# Altium schematic sheet TC106_v012.SchDoc(TC106_v012)
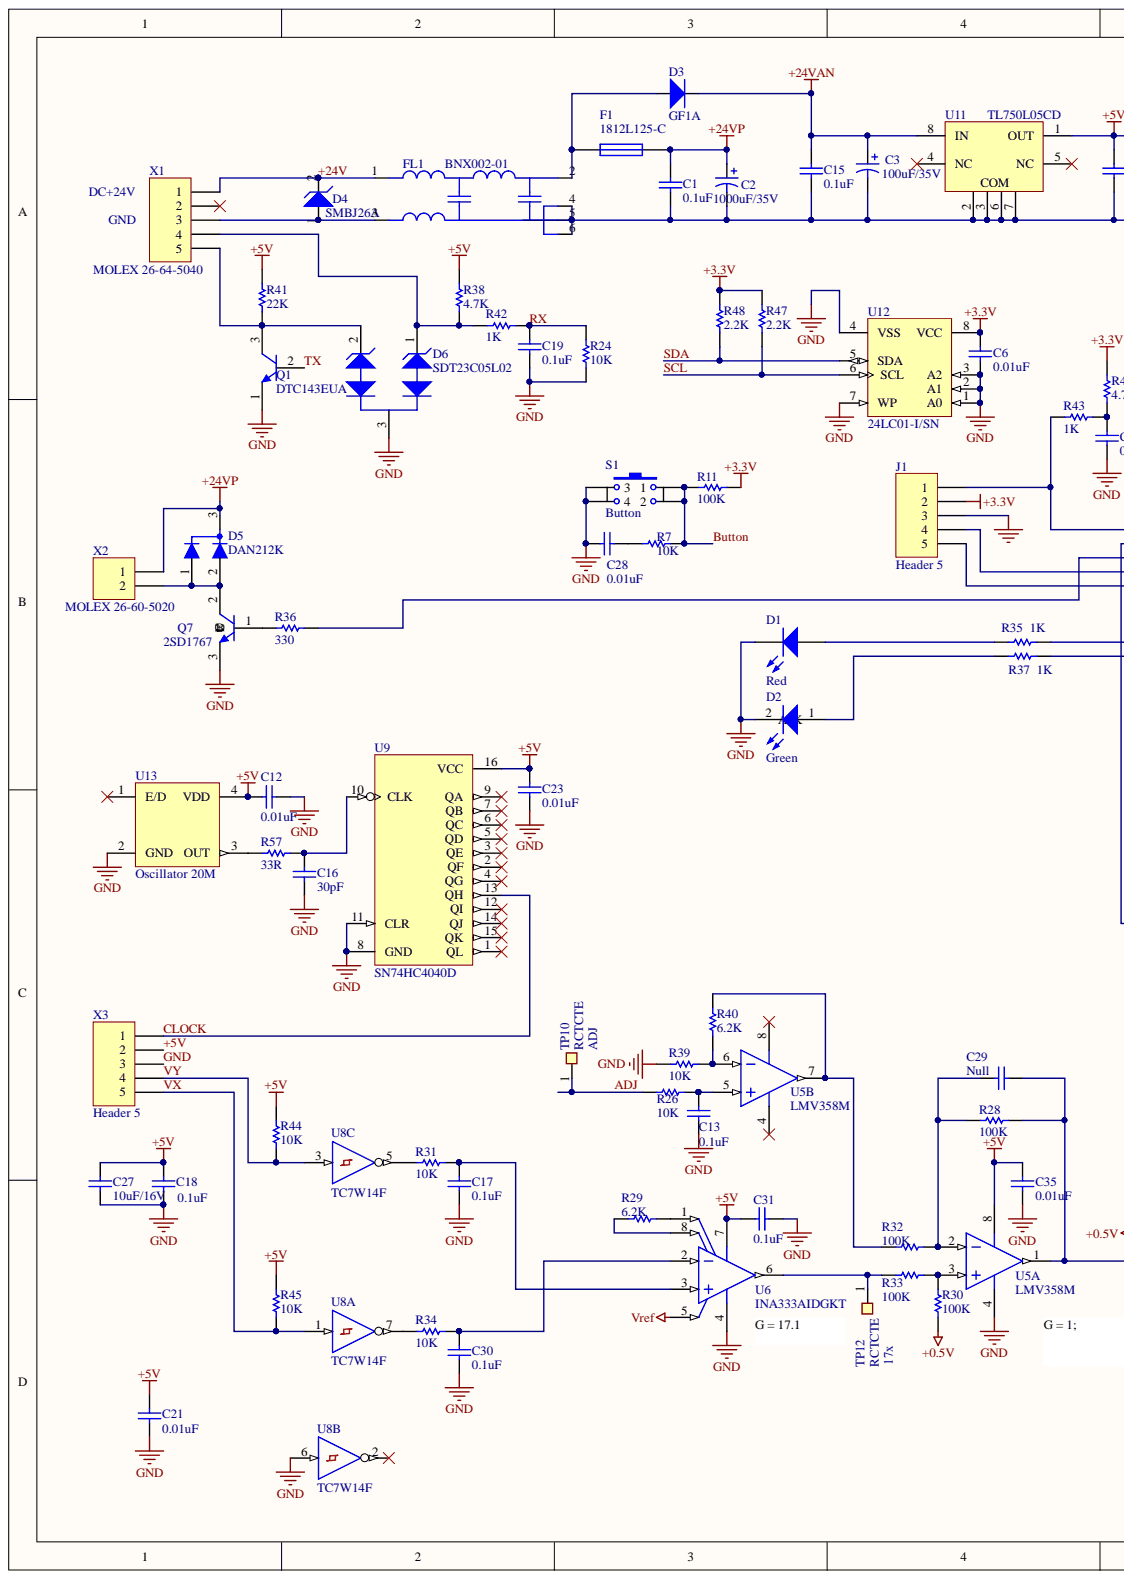
[diagram p0: TC106_v012.SchDoc, left]
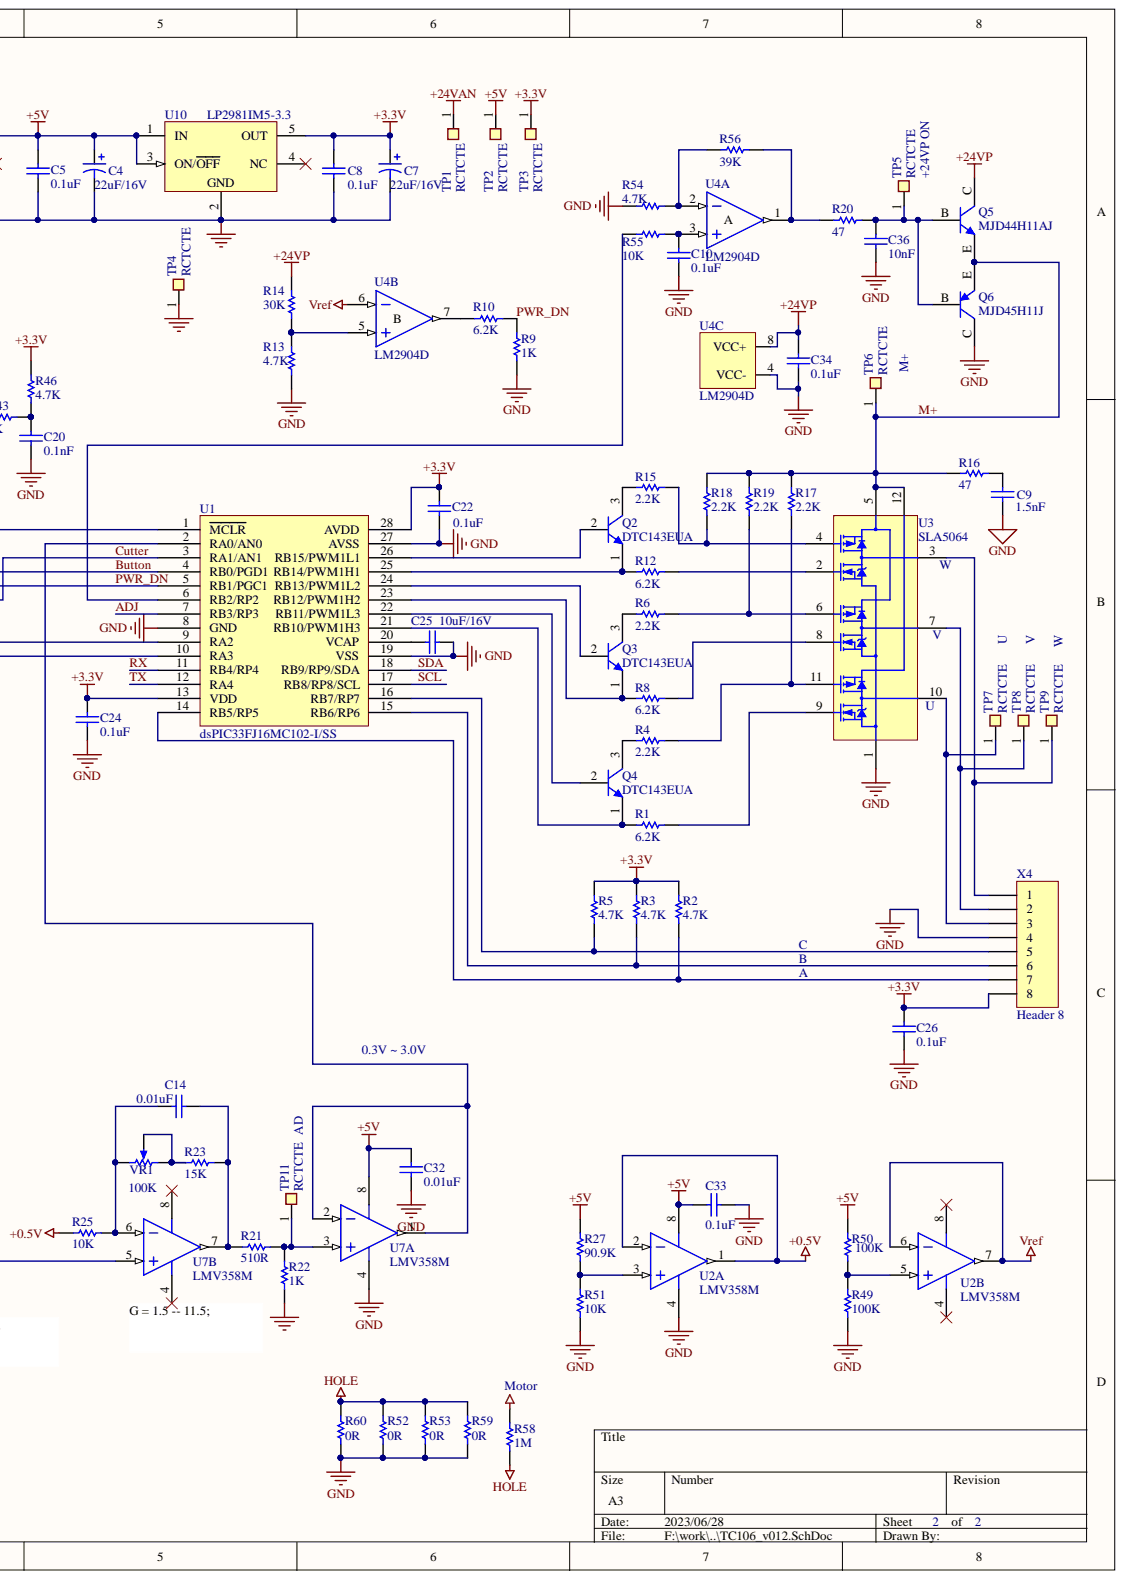
[diagram p1: TC106_v012.SchDoc, right]

## Parts
C1  0.1uF  [C0805-D]
C2  1000uF/35V  [RB.2/.5_M]
C3  100uF/35V  [E CAP D]
C4  22uF/16V  [E CAP B]
C5  0.1uF  [C0805-D]
C6  0.01uF  [C0805-D]
C7  22uF/16V  [E CAP B]
C8  0.1uF  [C0805-D]
C9  1.5nF  [C0805-D]
C10  0.1uF  [C0805-D]
C12  0.01uF  [C0805-D]
C13  0.1uF  [C0805-D]
C14  0.01uF  [C0805-D]
C15  0.1uF  [C0805-D]
C16  30pF  [C0805-D]
C17  0.1uF  [C0805-D]
C18  0.1uF  [C0805-D]
C19  0.1uF  [C0805-D]
C20  0.1nF  [C0805-D]
C21  0.01uF  [C0805-D]
C22  0.1uF  [C0805-D]
C23  0.01uF  [C0805-D]
C24  0.1uF  [C0805-D]
C25  10uF/16V  [C0805-D]
C26  0.1uF  [C0805-D]
C27  10uF/16V  [C0805-D]
C28  0.01uF  [C0805-D]
C29  Null  [C0805-D]
C30  0.1uF  [C0805-D]
C31  0.1uF  [C0805-D]
C32  0.01uF  [C0805-D]
C33  0.1uF  [C0805-D]
C34  0.1uF  [C0805-D]
C35  0.01uF  [C0805-D]
C36  10nF  [C0805-D]
D1  Red  [LED1206-D]
D2  Green  [LED1206-D]
D3  GF1A  [SMA]
D4  SMBJ26A  [Diode-SMBJ]
D5  DAN212K  [SOT23_B]
D6  SDT23C05L02  [sot23]
F1  1812L125-C  [R1812-D]
FL1  BNX002-01  [FILTER_BNX002-01]
J1  Header 5  [HDR1X5]
Motor  Motor-BLDC45  [Motor_BLDC45]  (value not drawn)
Q1  DTC143EUA  [SOT323B]
Q2  DTC143EUA  [SOT323B]
Q3  DTC143EUA  [SOT323B]
Q4  DTC143EUA  [SOT323B]
Q5  MJD44H11AJ  [MJD44H11AJ]
Q6  MJD45H11J  [MJD45H11J]
Q7  2SD1767  [SOT89]
R1  6.2K  [R0805-D]
R2  4.7K  [R0805-D]
R3  4.7K  [R0805-D]
R4  2.2K  [R0805-D]
R5  4.7K  [R0805-D]
R6  2.2K  [R0805-D]
R7  10K  [R0805-D]
R8  6.2K  [R0805-D]
R9  1K  [R0805-D]
R10  6.2K  [R0805-D]
R11  100K  [R0805-D]
R12  6.2K  [R0805-D]
R13  4.7K  [R0805-D]
R14  30K  [R0805-D]
R15  2.2K  [R0805-D]
R16  47  [R0805-D]
R17  2.2K  [R0805-D]
R18  2.2K  [R0805-D]
R19  2.2K  [R0805-D]
R20  47  [R0805-D]
R21  510R  [R0805-D]
R22  1K  [R0805-D]
R23  15K  [R0805-D]
R24  10K  [R0805-D]
R25  10K  [R0805-D]
R26  10K  [R0805-D]
R27  90.9K  [R0805-D]
R28  100K  [R0805-D]
R29  6.2K  [R0805-D]
R30  100K  [R0805-D]
R31  10K  [R0805-D]
R32  100K  [R0805-D]
R33  100K  [R0805-D]
R34  10K  [R0805-D]
R35  1K  [R0805-D]
R36  330  [R0805-D]
R37  1K  [R0805-D]
R38  4.7K  [R0805-D]
R39  10K  [R0805-D]
R40  6.2K  [R0805-D]
R41  22K  [R0805-D]
R42  1K  [R0805-D]
R43  1K  [R0805-D]
R44  10K  [R0805-D]
R45  10K  [R0805-D]
R46  4.7K  [R0805-D]
R47  2.2K  [R0805-D]
R48  2.2K  [R0805-D]
R49  100K  [R0805-D]
R50  100K  [R0805-D]
R51  10K  [R0805-D]
R52  0R  [R0805-D]
R53  0R  [R0805-D]
R54  4.7K  [R0805-D]
R55  10K  [R0805-D]
R56  39K  [R0805-D]
R57  33R  [R0805-D]
R58  1M  [R0805-D]
R59  0R  [R0805-D]
R60  0R  [R0805-D]
S1  Button  [sw_button 6*6]
TP1  RCTCTE  [RCTCTE]
TP2  RCTCTE  [RCTCTE]
TP3  RCTCTE  [RCTCTE]
TP4  RCTCTE  [RCTCTE]
TP5  RCTCTE  [RCTCTE]
TP6  RCTCTE  [RCTCTE]
TP7  RCTCTE  [RCTCTE]
TP8  RCTCTE  [RCTCTE]
TP9  RCTCTE  [RCTCTE]
TP10  RCTCTE  [RCTCTE]
TP11  RCTCTE  [RCTCTE]
TP12  RCTCTE  [RCTCTE]
U1  dsPIC33FJ16MC102-I/SS  [SSOP-SS28_M]
U2  LMV358M  [M08A_M]  (parts A,B)
U3  SLA5064  [SLA5064]
U4  LM2904D  [SO-8]  (parts A,B,C)
U5  LMV358M  [M08A_M]  (parts A,B)
U6  INA333AIDGKT  [TI-DGK8_M]
U7  LMV358M  [M08A_M]  (parts A,B)
U8  TC7W14F  [TC7W14FU]  (parts A,B,C)
U9  SN74HC4040D  [SO-16]
U10  LP2981IM5-3.3  [MF05A_N]
U11  TL750L05CD  [TI-D8_N]
U12  24LC01-I/SN  [SO-8]
U13  Oscillator 20M  [OSC7050]
VR1  100K  [POT-3266W-1]
X1  MOLEX 26-64-5040  [MOLEX 26-64-5040]
X2  MOLEX 26-60-5020  [MOLEX 26-60-5020]
X3  Header 5  [molex 52271-0569]
X4  Header 8  [HX25035-8A]

## Nets
+0.5V: R25.1 R30.1 U2.1 U2.2
+3.3V: C6.2 C7.1 C8.2 C22.2 C24.2 C26.1 J1.2 R2.2 R3.2 R5.2 R11.1 R46.1 R47.2 R48.2 TP3.1 U1.13(VDD) U1.28(AVDD) U10.5(OUT) U12.8(VCC) X4.8
+24VAN: C3.1 C15.2 D3.2 TP1.1 U11.8(IN)
+24VP: C1.2 C2.1 C34.2 D5.3 F1.2 Q5.C R14.2 U4.8(VCC+) X2.1
HOLE: R52.1 R53.1 R58.1 R59.1 R60.1
Vref: U2.6 U2.7 U4.6 U6.5
+5V: C4.1 C5.2 C12.2 C18.2 C21.1 C23.2 C27.1 C31.1 C32.1 C33.1 C35.1 R27.2 R38.2 R41.2 R44.2 R45.2 R50.2 TP2.1 U2.8 U5.8 U6.7 U7.8 U8.8 U9.16(VCC) U10.1(IN) U10.3(ON/OFF) U11.1(OUT) U13.4(VDD) X3.2
+24V: D4.2 FL1.1 X1.1
ADJ: R26.2 TP10.1 U1.7(RB3/RP3)
Button: J1.4 R7.1 R11.2 S1.1 S1.2 U1.4(RB0/PGD1)
CLOCK: U9.13(QH) X3.1
Cutter: R36.2 U1.3(RA1/AN1)
GND: C1.1 C2.2 C3.2 C4.2 C5.1 C6.1 C7.2 C8.1 C9.1 C10.1 C12.1 C13.1 C15.1 C16.1 C17.1 C18.1 C19.1 C20.1 C21.2 C22.1 C23.1 C24.1 C25.1 C26.2 C27.2 C28.1 C30.1 C31.2 C32.2 C33.2 C34.1 C35.2 C36.1 D1.2 D2.2 D6.3 FL1.4 FL1.5 FL1.6 J1.3 Q1.1 Q6.C Q7.3 R9.1 R13.1 R22.2 R24.1 R39.2 R49.1 R51.1 R52.2 R53.2 R54.1 R59.2 R60.2 S1.3 S1.4 TP4.1 U1.8(GND) U1.19(VSS) U1.27(AVSS) U2.4 U3.1 U4.4(VCC-) U5.4 U6.4 U7.4 U8.4 U8.6 U9.8(GND) U9.11(CLR) U10.2 U11.2 U11.3 U11.6 U11.7 U12.1(A0) U12.2(A1) U12.3(A2) U12.4(VSS) U12.7(WP) U13.2(GND) X3.3 X4.4
M+: Q5.E Q6.E R16.2 R17.2 R18.2 R19.1 TP6.1 U3.5 U3.12
PWR_DN: J1.5 R9.2 R10.1 U1.5(RB1/PGC1)
RX: C19.2 R24.2 R42.1 U1.11(RB4/RP4)
SCL: R47.1 U1.17(RB8/RP8/SCL) U12.6(SCL)
SDA: R48.1 U1.18(RB9/RP9/SDA) U12.5(SDA)
TX: Q1.2 U1.12(RA4)
VX: R45.1 U8.1 X3.5
VY: R44.1 U8.3 X3.4
NetC9_2: C9.2 R16.1
NetC10_2: C10.2 R55.1 U4.3
NetC13_2: C13.2 R26.1 U5.5
NetC14_1: C14.1 R25.2 U7.6 VR1.2
NetC14_2: C14.2 R21.2 R23.2 U7.7
NetC16_2: C16.2 R57.2 U9.10(CLK)
NetC17_2: C17.2 R31.2 U6.3
NetC20_2: C20.2 R43.1 R46.2
NetC25_2: C25.2 U1.20(VCAP)
NetC28_2: C28.2 R7.2
NetC29_1: C29.1 R28.1 R32.2 U5.2
NetC29_2: C29.2 R28.2 U5.1 U7.5
NetC30_2: C30.2 R34.2 U6.2
NetC36_2: C36.2 Q5.B Q6.B R20.2 TP5.1
NetD1_1: D1.1 R35.2
NetD2_1: D2.1 R37.2
NetD3_1: D3.1 F1.1 FL1.2
NetD4_1: D4.1 FL1.3 X1.3
NetD5_1: D5.1 D5.2 Q7.2 X2.2
NetD6_1: D6.1 R38.1 R42.2 X1.4
NetD6_2: D6.2 Q1.3 R41.1 X1.5
NetJ1_1: J1.1 R43.2 U1.1(MCLR)
NetMotor_1: Motor.1 R58.2
NetQ2_1: Q2.1 R12.1 U1.25(RB14/PWM1H1)
NetQ2_2: Q2.2 U1.26(RB15/PWM1L1)
NetQ2_3: Q2.3 R15.1
NetQ3_1: Q3.1 R8.1 U1.23(RB12/PWM1H2)
NetQ3_2: Q3.2 U1.24(RB13/PWM1L2)
NetQ3_3: Q3.3 R6.1
NetQ4_1: Q4.1 R1.1 U1.21(RB10/PWM1H3)
NetQ4_2: Q4.2 U1.22(RB11/PWM1L3)
NetQ4_3: Q4.3 R4.1
NetQ7_1: Q7.1 R36.1
NetR1_2: R1.2 U3.9
NetR2_1: R2.1 U1.14(RB5/RP5) X4.7
NetR3_1: R3.1 U1.15(RB6/RP6) X4.6
NetR4_2: R4.2 R17.1 U3.11
NetR5_1: R5.1 U1.16(RB7/RP7) X4.5
NetR6_2: R6.2 R19.2 U3.6
NetR8_2: R8.2 U3.8
NetR10_2: R10.2 U4.7
NetR12_2: R12.2 U3.2
NetR13_2: R13.2 R14.1 U4.5
NetR15_2: R15.2 R18.1 U3.4
NetR20_1: R20.1 R56.1 U4.1
NetR21_1: R21.1 R22.1 TP11.1 U7.3
NetR23_1: R23.1 VR1.1 VR1.3
NetR27_1: R27.1 R51.2 U2.3
NetR29_1: R29.1 U6.8
NetR29_2: R29.2 U6.1
NetR30_2: R30.2 R33.2 U5.3
NetR31_1: R31.1 U8.5
NetR32_1: R32.1 R40.2 U5.7
NetR33_1: R33.1 TP12.1 U6.6
NetR34_1: R34.1 U8.7
NetR35_1: R35.1 U1.9(RA2)
NetR37_1: R37.1 U1.10(RA3)
NetR39_1: R39.1 R40.1 U5.6
NetR49_2: R49.2 R50.1 U2.5
NetR54_2: R54.2 R56.2 U4.2
NetR55_2: R55.2 U1.6(RB2/RP2)
NetR57_1: R57.1 U13.3(OUT)
NetTP7_1: TP7.1 U3.10 X4.3
NetTP8_1: TP8.1 U3.7 X4.2
NetTP9_1: TP9.1 U3.3 X4.1
NetU1_2: U1.2(RA0/AN0) U7.1 U7.2

Not connected: U8 2; U9 1(QL) 2(QF) 3(QE) 4(QG) 5(QD) 6(QC) 7(QB) 9(QA) 12(QI) 14(QJ) 15(QK); U10 4(NC); U11 4(NC) 5(NC); U13 1(E/D); X1 2

## Library data of the parts
C1 C6 C10 C12 C14 C17 C22 C23 C26 C30 C31 = Cap: Capacitor; Simulation: CAP; Signal Integrity: Cap; Published: 8-Jun-2000; PackageReference: RAD-0.3; Library Name: Miscellaneous Devices.IntLib; LatestRevisionNote: Re-released for DXP Platform.; LatestRevisionDate: 17-Jul-2002; Component Kind: Standard; Publisher: Altium Limited
  C1 C10 C17 C22 C26 C30 C31: Value: 0.1uF
  C6 C12 C14 C23: Value: 0.01uF
C2 C4 C7 = Cap Pol2: Polarized Capacitor (Axial); Simulation: CAP; Signal Integrity: Cap Pol; Published: 8-Jun-2000; PackageReference: POLAR0.8; Library Name: Miscellaneous Devices.IntLib; LatestRevisionNote: Re-released for DXP Platform.; LatestRevisionDate: 17-Jul-2002; Component Kind: Standard; Publisher: Altium Limited
  C2: Value: 1000uF/35V
  C4 C7: Value: 22uF/16V
C3 = Cap Pol2: Polarized Capacitor (Axial); Value: 22uF/35V; Simulation: CAP; Signal Integrity: Cap Pol; Published: 8-Jun-2000; PackageReference: POLAR0.8; Library Name: Miscellaneous Devices.IntLib; LatestRevisionNote: Re-released for DXP Platform.; LatestRevisionDate: 17-Jul-2002; Component Kind: Standard; Publisher: Altium Limited
C5 = Cap: Capacitor; Value: 100nF; Simulation: CAP; Signal Integrity: Cap; Published: 8-Jun-2000; PackageReference: RAD-0.3; Library Name: my_pcblib.pcblib; LatestRevisionNote: Re-released for DXP Platform.; LatestRevisionDate: 17-Jul-2002; Component Kind: Standard; Publisher: Altium Limited
C8 C13 C16 C24 C33 C34 = Cap: Capacitor; Value: 0.01uF; Simulation: CAP; Signal Integrity: Cap; Published: 8-Jun-2000; PackageReference: RAD-0.3; Library Name: Miscellaneous Devices.IntLib; LatestRevisionNote: Re-released for DXP Platform.; LatestRevisionDate: 17-Jul-2002; Component Kind: Standard; Publisher: Altium Limited
C9 = Cap: Capacitor; Value: 2.2nF; Simulation: CAP; Signal Integrity: Cap; Published: 8-Jun-2000; PackageReference: RAD-0.3; Library Name: Miscellaneous Devices.IntLib; LatestRevisionNote: Re-released for DXP Platform.; LatestRevisionDate: 17-Jul-2002; Component Kind: Standard; Publisher: Altium Limited
C15 C19 = Cap: Capacitor; Value: 0.22uF; Simulation: CAP; Signal Integrity: Cap; Published: 8-Jun-2000; PackageReference: RAD-0.3; Library Name: Miscellaneous Devices.IntLib; LatestRevisionNote: Re-released for DXP Platform.; LatestRevisionDate: 17-Jul-2002; Component Kind: Standard; Publisher: Altium Limited
C18 = Cap: Capacitor; Value: 1uF; Simulation: CAP; Signal Integrity: Cap; Published: 8-Jun-2000; PackageReference: RAD-0.3; Library Name: Miscellaneous Devices.IntLib; LatestRevisionNote: Re-released for DXP Platform.; LatestRevisionDate: 17-Jul-2002; Component Kind: Standard; Publisher: Altium Limited
C20 C36 = Cap: Capacitor; Value: 1500pF; Simulation: CAP; Signal Integrity: Cap; Published: 8-Jun-2000; PackageReference: RAD-0.3; Library Name: Miscellaneous Devices.IntLib; LatestRevisionNote: Re-released for DXP Platform.; LatestRevisionDate: 17-Jul-2002; Component Kind: Standard; Publisher: Altium Limited
C21 = Cap: Capacitor; Value: 0.01nF; Simulation: CAP; Signal Integrity: Cap; Published: 8-Jun-2000; PackageReference: RAD-0.3; Library Name: Miscellaneous Devices.IntLib; LatestRevisionNote: Re-released for DXP Platform.; LatestRevisionDate: 17-Jul-2002; Component Kind: Standard; Publisher: Altium Limited
C25 C27 = Cap: Capacitor; Value: 10uF; Simulation: CAP; Signal Integrity: Cap; Published: 8-Jun-2000; PackageReference: RAD-0.3; Library Name: Miscellaneous Devices.IntLib; LatestRevisionNote: Re-released for DXP Platform.; LatestRevisionDate: 17-Jul-2002; Component Kind: Standard; Publisher: Altium Limited
C28 = Cap Semi: Capacitor (Semiconductor SIM Model); Value: 100pF; Simulation: CAP; Signal Integrity: Cap Semi; Published: 8-Jun-2000; PackageReference: C1206; Library Name: my Devices.SchLib; LatestRevisionNote: Re-released for DXP Platform.; LatestRevisionDate: 17-Jul-2002; Component Kind: Standard; Publisher: Altium Limited
C29 C32 C35 = Cap: Capacitor; Value: 0.1uF; Simulation: CAP; Signal Integrity: Cap; Published: 8-Jun-2000; PackageReference: RAD-0.3; Library Name: Miscellaneous Devices.IntLib; LatestRevisionNote: Re-released for DXP Platform.; LatestRevisionDate: 17-Jul-2002; Component Kind: Standard; Publisher: Altium Limited
D1 D2 = LED2: Typical RED, GREEN, YELLOW, AMBER GaAs LED; Simulation: LED2; Published: 8-Jun-2000; PackageReference: 3.2X1.6X1.1; PackageDocument: Feb-2002; Library Name: Miscellaneous Devices.IntLib; LatestRevisionNote: Re-released for DXP Platform.; LatestRevisionDate: 17-Jul-2002; Component Kind: Standard; Publisher: Altium Limited
D3 = Diode: Default Diode; Simulation: DIODE; Signal Integrity: Diode; Published: 8-Jun-2000; PackageReference: SMC; PackageDocument: Sep-1996; Library Name: my Devices.SchLib; LatestRevisionNote: Re-released for DXP Platform.; LatestRevisionDate: 17-Jul-2002; Component Kind: Standard; Code_JEDEC: DO-214-AB; Publisher: Altium Limited
D4 = Diode TVS: TVS,VR 26V,600w,SMB,UL RoHs; Simulation: DIODE; Signal Integrity: Diode; Published: 8-Jun-2000; PackageReference: SMC; PackageDocument: Sep-1996; Library Name: my Devices.SchLib; LatestRevisionNote: Re-released for DXP Platform.; LatestRevisionDate: 17-Jul-2002; Component Kind: Standard; Code_JEDEC: DO-214-AB; Publisher: Altium Limited
D6 = AD4492: DIODE, TRANSIENT SUPPRESSOR, DUAL, BIDIRECTIONAL, 5V, SOT23, LF; State: Released; ID: 69; DCOMP: PESD5V0S2BT; Database Table Name: Altium.AD-Diodes; Catalog: Transient Suppressors; Supplier Part Number 3: 38K6670; Supplier Part Number 2: 771-PESD5V0S2BT-T/R; Supplier Part Number 1: 568-4056-2-ND; Supplier 3: Newark; Supplier 2: Mouser; Supplier 1: Digi-Key; RoHS: RoHS2011; Part Number: AD4492; Component Kind: Standard; BOM_PN: AD4492; Library Name: CSH_DB_PROD.DbLib
F1 = Fuse 1: Fuse; Simulation: FUSE; Published: 8-Jun-2000; PackageReference: PIN-W2/E2.8; Library Name: Miscellaneous Devices.IntLib; LatestRevisionNote: Re-released for DXP Platform.; LatestRevisionDate: 17-Jul-2002; Component Kind: Standard; Publisher: Altium Limited
FL1 = 903884: FILTER,SINGLE,.5MHZ-1GHZ,50VDC, LF; State: Released; ID: 1; Database Table Name: Altium.AD-Misc; Catalog: Elect and EMI/RFI Filters; Supplier Part Number 3: 69K8511; Supplier Part Number 2: BNX002-01; Supplier Part Number 1: 490-5053-ND; Supplier 3: Newark; Supplier 2: Mouser; Supplier 1: Digi-Key; RoHS: RoHS2011; Part Number: 903884; DCOMP: BNX002-01; Component Kind: Standard; BOM_PN: 903884; Library Name: CSH_DB_PROD.DbLib
J1 X1 X3 = Header 5: Header, 5-Pin; Signal Integrity: Connector; Library Name: Miscellaneous Connectors.IntLib; LatestRevisionNote: Re-released for DXP Platform.; LatestRevisionDate: 17-Jul-2002; Component Kind: Standard; Publisher: Altium Limited
Q1-Q4 = NPN: NPN Bipolar Transistor; Simulation: NPN; Signal Integrity: NPN; Published: 8-Jun-2000; PackageReference: TO-226; Library Name: Miscellaneous Devices.IntLib; LatestRevisionNote: Re-released for DXP Platform.; LatestRevisionDate: 17-Jul-2002; Component Kind: Standard; Code_JEDEC: TO-226-AA; Publisher: Altium Limited
Q5 Q7 = 2N3904: NPN General Purpose Amplifier; Simulation: 2N3904; Signal Integrity: 2N3904; Published: 8-Jun-2000; PackageReference: TO-92A; PackageDocument: Sep-1998; Library Name: Miscellaneous Devices.IntLib; LatestRevisionNote: PCB Footprint 'BCY-W3/D4.7' replaced; LatestRevisionDate: 15-Jan-2003; DatasheetDocument: 1997; ComponentLink2URL: http://www.fairchildsemi.com/ds/2N%2F2N3904.pdf; ComponentLink2Description: Datasheet; ComponentLink1URL: http://www.fairchildsemi.com/; ComponentLink1Description: Manufacturer Link; Component Kind: Standard; Code_JEDEC: TO-92A; Publisher: Altium Limited
Q6 = 2N3906: PNP General Purpose Amplifier; Simulation: 2N3906; Signal Integrity: 2N3906; Published: 8-Jun-2000; PackageReference: TO-92A; PackageDocument: Sep-1998; Library Name: Miscellaneous Devices.IntLib; LatestRevisionNote: PCB Footprint 'BCY-W3/D4.7' replaced; LatestRevisionDate: 15-Jan-2003; DatasheetDocument: 1997; ComponentLink2URL: http://www.fairchildsemi.com/ds/2N%2F2N3906.pdf; ComponentLink2Description: Datasheet; ComponentLink1URL: http://www.fairchildsemi.com/; ComponentLink1Description: Manufacturer Link; Component Kind: Standard; Code_JEDEC: TO-92A; Publisher: Altium Limited
R1-R60 = Res1: Resistor; Simulation: RESISTOR; Signal Integrity: Res; Published: 8-Jun-2000; Library Name: Miscellaneous Devices.IntLib; LatestRevisionNote: Re-released for DXP Platform.; LatestRevisionDate: 17-Jul-2002; Component Kind: Standard; Publisher: Altium Limited
S1 = SW-PB_1: Switch; Published: 24-Mar-1999; PackageReference: SPST-2; Library Name: my Devices.SchLib; LatestRevisionNote: Re-released for DXP Platform.; LatestRevisionDate: 17-Jul-2002; Component Kind: Standard; Publisher: Altium Limited
TP1-TP12 = TP: Component Kind: Standard; Library Name: Asena.lib
U1 = dsPIC33FJ16MC102-I/SS: General Purpose 16-Bit Flash Microcontroller, 16KB Flash, 1KB SRAM, 28-Pin SSOP, Industrial Temperature; Library Name: my Devices.SchLib; Component Kind: Standard
U2 U5 U7 = LMV358M: General Purpose, Low Voltage, Rail-to-Rail Output Operational Amplifiers, 8-pin Narrow SOIC; Manufacturer: National Semiconductor; PowerWise: N; PackageTopMarking: NSZXTT*LMV*358M; High-Reliability: Y; RoHS: N; PinCount: 8; PartNumber: LMV358M; PackageVersion: revM, May-2009; PackageReference: M08A; PackageDescription: SOIC Narrow, 4.9 x 3.9 x 1.45mm, 8 Lead, 1.27mm Pitch; Library Name: National Semiconductor Amplifier.IntLib; DatasheetVersion: Sep-2009; CompType: Amplifiers; ComponentLink4URL: http://www.national.com/packaging/folders/m08a.html; ComponentLink4Description: M08A Package Page; ComponentLink3URL: http://www.national.com/pf/LM/LMV358.html; ComponentLink3Description: LMV358 Web Page; ComponentLink2URL: http://www.national.com/ds/LM/LMV358.pdf; ComponentLink2Description: LMV358 Datasheet; ComponentLink1URL: http://www.national.com/; ComponentLink1Description: Manufacturer URL; Component Kind: Standard; Code_JEDEC: MS-012-AA; Code_IPC: SOIC127P600-8
U3 = SLA5064: N-channel+P-channel, 3-phase motor drive; Library Name: my Devices.SchLib; Component Kind: Standard
U4 = LM2904D: Low-Power Dual Operational Amplifier; Simulation: LM2904; Published: 24-Aug-2001; PCB3D: SO8; PackageVersion: Dec-2002; PackageReference: SO8; PackageDescription: 8-Pin Small Outline Integrated Circuit 1.27 mm Pitch; Library Name: TC106_v012.SCHLIB; LatestRevisionNote: IPC-7351 Footprint Added.; LatestRevisionDate: 13-Apr-2006; DatasheetVersion: Jan-1999; ComponentLink2URL: http://www.st.com/stonline/products/literature/ds/2471/lm2904.pdf; ComponentLink2Description: Datasheet; ComponentLink1URL: http://www.st.com/; ComponentLink1Description: Manufacturer Link; Component Kind: Standard; Code_JEDEC: MS-012-AA; Code_IPC: SOIC127P600-8; Publisher: Altium Limited
U6 = INA333AIDGKT: Low Power, Precision Instrumentation Amplifier, -40 to 125 degC, 8-pin SOP (DGK8), Green (RoHS & no Sb/Br); Manufacturer: Texas Instruments; Vs(Min)(V): 1.8; Vs(Max)(V): 5.5; SubFamily: Single Supply; Quiescent Current (+/-)(Typ)(mA): 0.05; Output Offset Drift (+/-)(Max)(uV/Degrees Celsius): 0.5/G; Output Offset (+/-)(Max)(uV): 75/G; Number of Channels: 1; Noise at 1kHz(Typ)(nV/rt(Hz)): 50; Input Offset Drift (+/-)(Max)(uV/Degrees Celsius): 0.1; Input Offset (+/-)(Max)(uV): 25; Input Bias Current (+/-)(Max)(nA): 0.2; Gain(V/V): 1 to 1000; CMRR(Min)(dB): 100; Supplier Part Number 7: 595-INA333AIDGKT; Supplier Part Number 6: 03P4517; Supplier Part Number 5: 86W6747; Supplier Part Number 4: 1645386; Supplier Part Number 3: 296-23565-6-ND; Supplier Part Number 2: 296-23565-1-ND; Supplier Part Number 1: 296-23565-2-ND; Supplier 7: Mouser; Supplier 6: Newark; Supplier 5: Newark; Supplier 4: Farnell; Supplier 3: Digi-Key; Supplier 2: Digi-Key; Supplier 1: Digi-Key; PartNumber: INA333AIDGKT; PackageVersion: 4073329/E, 05/2006; PackageReference: DGK8; PackageDescription: 8-Pin Thin Small Outline, Body 3 x 3 mm, Pitch 0.65 mm; Mounting Technology: Surface Mount; Library Name: TI Amplifiers and Linear Instrumentation Amplifier.IntLib; Eco-Plan: Green (RoHS & no Sb/Br); DatasheetVersion: SBOS445B; ComponentLink3URL: http://www.ti.com/litv/pdf/mpds028d; ComponentLink3Description: Package Specification; ComponentLink2URL: http://www.ti.com/general/docs/lit/getliterature.tsp?genericPartNumber=INA333&fileType=pdf; ComponentLink2Description: Datasheet; ComponentLink1URL: http://www.ti.com/; ComponentLink1Description: Manufacturer URL; Component Kind: Standard; Code_JEDEC: MO-187
U9 = SN74HC4040D: 12-Bit Asynchronous Binary Counter; Signal Integrity: SN74HC4040D; Published: 8-Jun-2000; PCB3D: D016; PackageVersion: Jan-1998; PackageReference: D016; PackageDescription: 16-Pin Small Outline Integrated Circuit 1.27 mm Pitch; Library Name: TI Logic Counter.IntLib; LatestRevisionNote: IPC-7351 Footprint Added.; LatestRevisionDate: 13-Apr-2006; DatasheetVersion: May-1997; ComponentLink2URL: http://www-s.ti.com/sc/ds/sn74hc4040.pdf; ComponentLink2Description: Datasheet; ComponentLink1URL: http://www.ti.com/; ComponentLink1Description: Manufacturer Link; Component Kind: Standard; Code_IPC: SOIC127P600-16; Publisher: Altium Limited
U10 = LP2981IM5-3.3: Micropower 100 mA Ultra Low-Dropout Regulator in SOT-23 and micro SMD Packages; Manufacturer: National Semiconductor; PowerWise: N; PackageTopMarking: L04B*XTT; CompType: LDO IC; RoHS: O; Published: 4-Dec-2008; PinCount: 5; PartNumber: LP2981IM5-3.3; PackageVersion: revD, Aug-2008; PackageReference: MF05A; PackageDescription: Molded SOT-23, .115 x .063 x .040 in Body, 5 Lead, .0375 in Pitch; Library Name: NSC LDO.IntLib; DigiKeyPartNumber: LP2981IM5-3.3TR-ND; DatasheetVersion: Feb-2006; ComponentLink3URL: http://www.national.com/packaging/folders/mf05a.html; ComponentLink3Description: MF05A Package Page; ComponentLink2URL: http://www.national.com/pf/LP/LP2981.html; ComponentLink2Description: LP2981 Web Page; ComponentLink1URL: http://www.national.com/ds/LP/LP2981.pdf; ComponentLink1Description: LP2981 Datasheet; Component Kind: Standard; Publisher: Altium Limited
U11 = TL750L05CD: Single Output LDO, 150 mA, Fixed 5 V Output, 6 to 26 V Input, with Low IQ, 8-pin SOIC (D), 0 to 125 degC, Green (RoHS & no Sb/Br); Manufacturer: Texas Instruments; Vout Adj(Min)(V): 5; Vin(Min)(V): 6; Vin(Max)(V): 26; Vdo(Typ)(mV): 600; SubFamily: Single Channel LDO; Rating: Catalog; Iq(Typ)(mA): 1; Iout(Max)(A): 0.15; Accuracy(%): 4; Vout Adj(Max)(V): 5; Supplier Part Number 4: 595-TL750L05CD; Supplier Part Number 3: 20C8680; Supplier Part Number 2: 9593659; Supplier Part Number 1: 296-8000-5-ND; Supplier 4: Mouser; Supplier 3: Newark; Supplier 2: Farnell; Supplier 1: Digi-Key; PartNumber: TL750L05CD; PackageVersion: 4040047-3/M; PackageReference: D8; PackageDescription: 8-Pin Plastic Small Outline, Body 4.9 x 3.9 mm, Pitch 1.27 mm; Mounting Technology: Surface Mount; Library Name: TI Power Management Linear Regulator Single Channel LDO (0-350mA).IntLib; Fixed Output Options(V): 5; Eco-Plan: Green (RoHS & no Sb/Br); DatasheetVersion: SLVS017U; ComponentLink4URL: Samples URL:http://www.ti.com/product/tl750l05#samples; ComponentLink4Description: URL Link1; ComponentLink3URL: http://www.ti.com/litv/pdf/msoi002j; ComponentLink3Description: Package Specification; ComponentLink2URL: http://www.ti.com/general/docs/lit/getliterature.tsp?genericPartNumber=TL750L05&fileType=pdf; ComponentLink2Description: Datasheet; ComponentLink1URL: http://www.ti.com/; ComponentLink1Description: Manufacturer URL; Component Kind: Standard; Code_JEDEC: MS-012
U12 = 24LC128-I/SN: 128Kbit, 400kHz, 2.5V, I2C Serial EEPROM, 8-Pin SOIC 150mil, Industrial Temperature; Published: 29-Jan-2009; PackageVersion: revAW, Oct-2008; PackageReference: SOIC-SN8; PackageDescription: 8-Lead Plastic Small Outline (SN) - Narrow, 3.90mm Body [SOIC]; Library Name: Microchip EEPROM I2C Serial.IntLib; DatasheetVersion: revQ, Jun-2008; ComponentLink3URL: http://ww1.microchip.com/downloads/en/PackagingSpec/00049AW.pdf; ComponentLink3Description: Packaging Specification; ComponentLink2URL: http://ww1.microchip.com/downloads/en/DeviceDoc/21191Q.pdf; ComponentLink2Description: Datasheet; ComponentLink1URL: http://www.microchip.com; ComponentLink1Description: Manufacturer Link; Component Kind: Standard; Code_JEDEC: MS-012; Code_IPC: SOIC127P600-8; Publisher: Altium Limited
U13 = Oscillator: Library Name: my_schlib.SchLib; Description: Oscillator; Component Kind: Standard
VR1 = RPot: Potentiometer; Sim Note: Enter the Resistance value and the set point into parameter fields.; Set Position: 0.5; Value: 100K; Simulation: POT; Published: 8-Jun-2000; PackageReference: VR5; Library Name: Miscellaneous Devices.IntLib; LatestRevisionNote: Re-released for DXP Platform.; LatestRevisionDate: 17-Jul-2002; Component Kind: Standard; Publisher: Altium Limited
X2 = Header 2: Header, 2-Pin; Signal Integrity: Connector; Library Name: Miscellaneous Connectors.IntLib; LatestRevisionNote: Re-released for DXP Platform.; LatestRevisionDate: 17-Jul-2002; Component Kind: Standard; Publisher: Altium Limited
X4 = Header 8: Header, 8-Pin; Signal Integrity: Connector; Library Name: my Devices.SchLib; LatestRevisionNote: Re-released for DXP Platform.; LatestRevisionDate: 17-Jul-2002; Component Kind: Standard; Publisher: Altium Limited
D5 = DAN212K: Library Name: TC106_v012.SCHLIB; Component Kind: Standard
Motor = Motor-BLDC45: Published: 24-Mar-1999; PackageReference: PIN2; Library Name: my Devices.SchLib; LatestRevisionNote: Re-released for DXP Platform.; LatestRevisionDate: 17-Jul-2002; Description: Motor-BLDC45; Component Kind: Standard; Publisher: Altium Limited
U8 = TC7W14F: Library Name: Miscellaneous Devices.SchLib; Component Kind: Standard
